# Altium schematic sheet Input_analog.SchDoc(Input_analog)
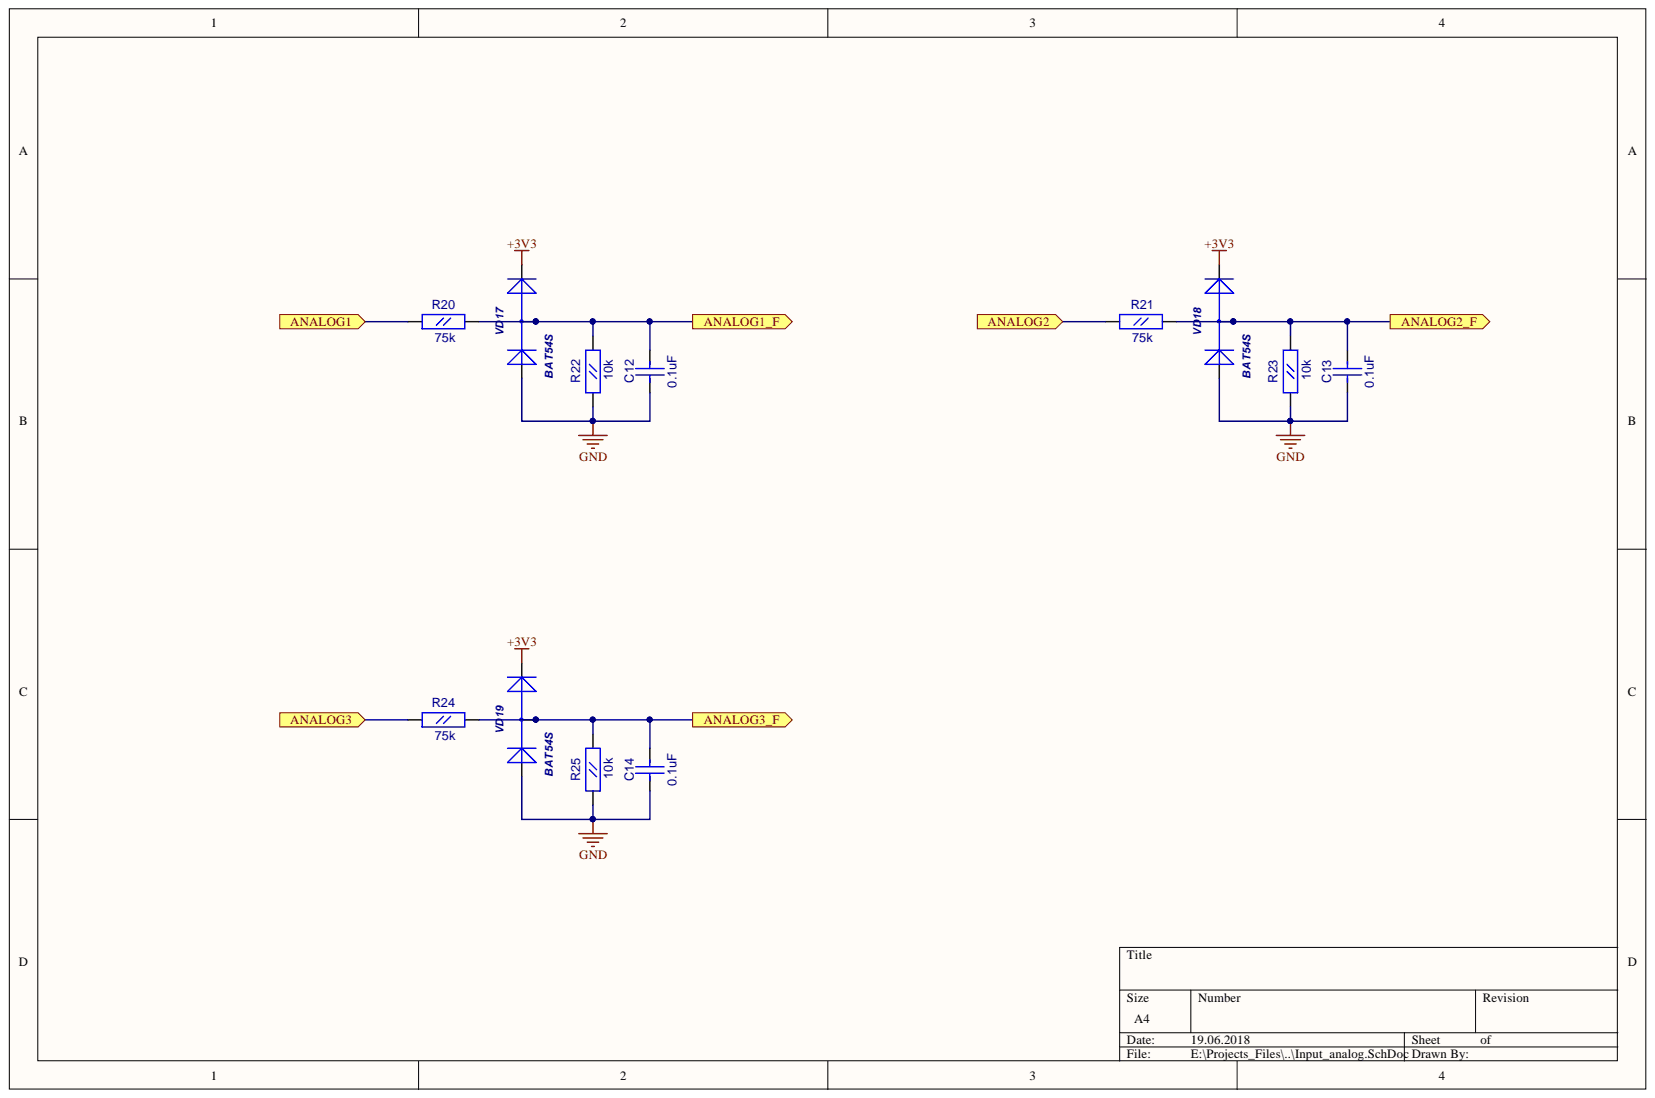
[diagram p0: Input_analog.SchDoc]

## Parts
C12  0.1uF  [CC0603]
C13  0.1uF  [CC0603]
C14  0.1uF  [CC0603]
R20  75k  [RC0603]
R21  75k  [RC0603]
R22  10k  [RC0603]
R23  10k  [RC0603]
R24  75k  [RC0603]
R25  10k  [RC0603]
VD17  BAT54S  [SOT-23(GSD)]
VD18  BAT54S  [SOT-23(GSD)]
VD19  BAT54S  [SOT-23(GSD)]

## Ports
ANALOG1, ANALOG1_F, ANALOG2, ANALOG2_F, ANALOG3, ANALOG3_F

## Nets
+3V3: VD17.2 VD18.2 VD19.2
GND: C12.1 C13.1 C14.1 R22.1 R23.1 R25.1 VD17.1 VD18.1 VD19.1
ANALOG1: R20.1 ; port ANALOG1
ANALOG1_F: C12.2 R20.2 R22.2 VD17.3 ; port ANALOG1_F
ANALOG2: R21.1 ; port ANALOG2
ANALOG2_F: C13.2 R21.2 R23.2 VD18.3 ; port ANALOG2_F
ANALOG3: R24.1 ; port ANALOG3
ANALOG3_F: C14.2 R24.2 R25.2 VD19.3 ; port ANALOG3_F

## Library data of the parts
C12-C14 = CC0603: Value: 0.1uF; Description: CC0603; Component Kind: Standard; Library Name: gprs_modem_2.SchLib
R20-R25 = RC0603: Description: RC0603; Component Kind: Standard; Library Name: gprs_modem_2.SchLib
  R20 R21 R24: Value: 75k
  R22 R23 R25: Value: 10k
VD17-VD19 = BAT54S: 1.0 Ampere General Purpose Rectifier; ComponentField6: Published 8-Jun-2000, Modified 11-July-2000: SIM Model Added.; Simulation: DIODE; Component Kind: Standard; Library Name: gprs_modem_2.SchLib
